# Altium schematic sheet 03_CEM_MBRD.SchDoc
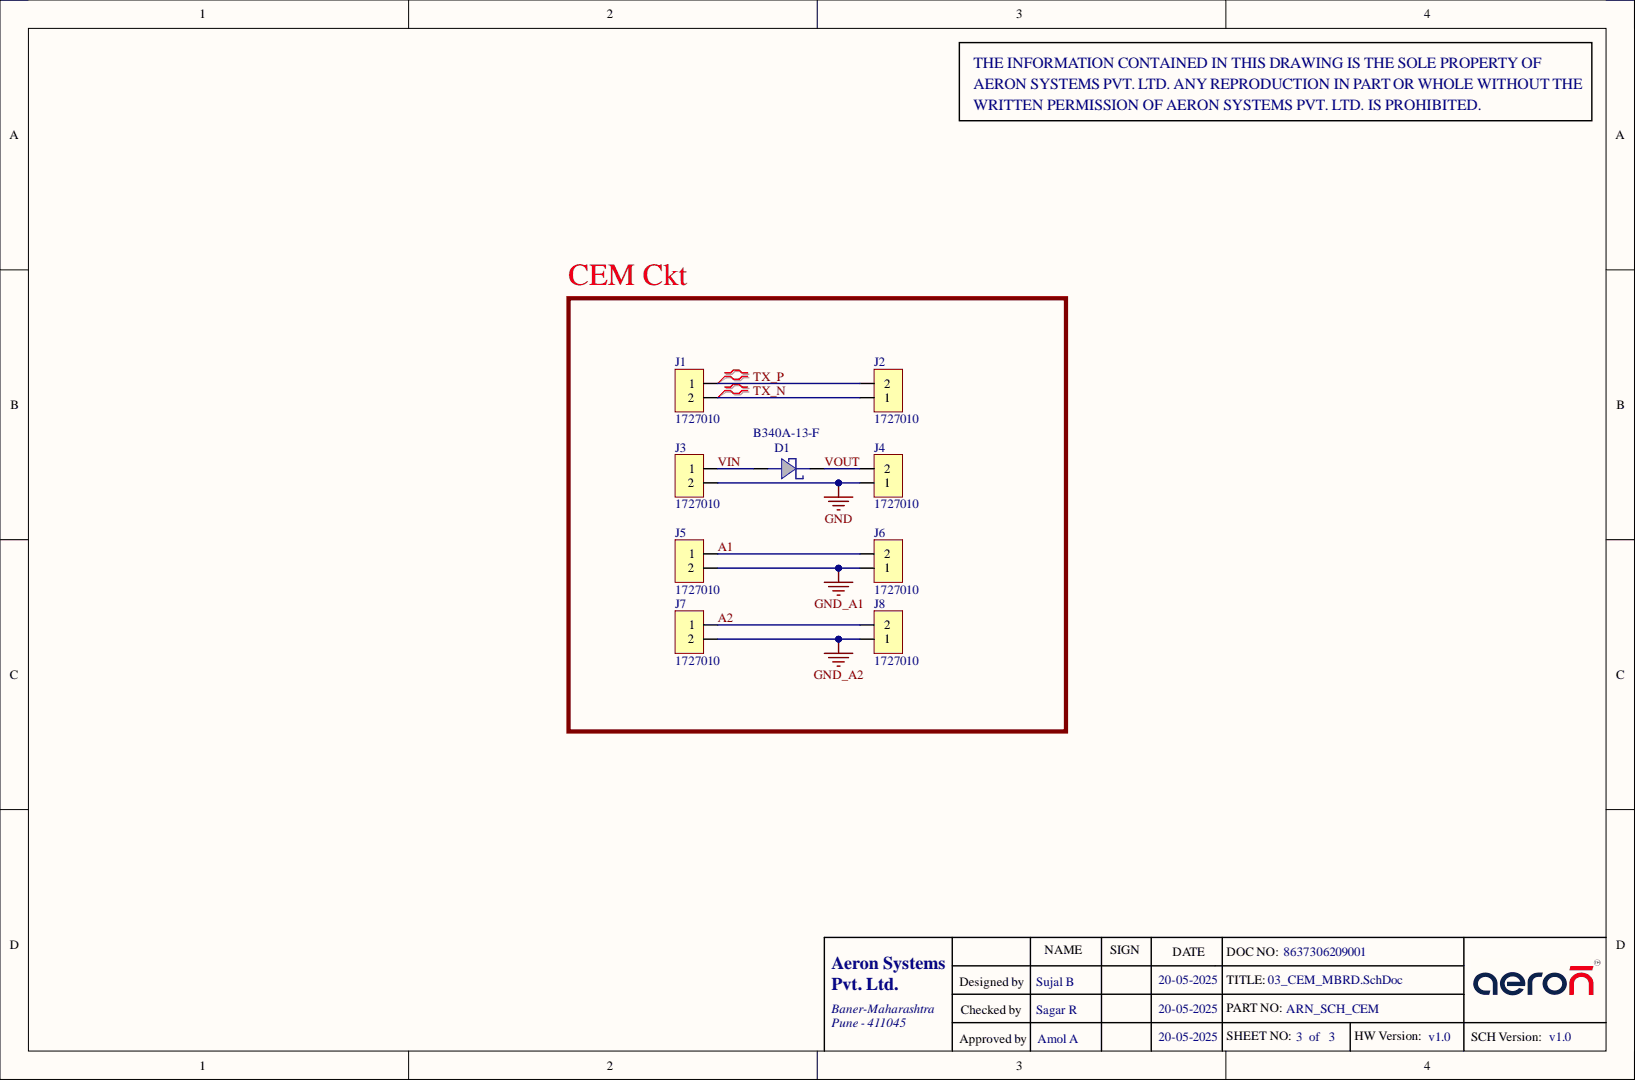
[diagram p0: 03_CEM_MBRD.SchDoc]

## Parts
D1  B340A-13-F  [DO-SMA]
J1  1727010  [CON 2 MKDS-3.81]
J2  1727010  [CON 2 MKDS-3.81]
J3  1727010  [CON 2 MKDS-3.81]
J4  1727010  [CON 2 MKDS-3.81]
J5  1727010  [CON 2 MKDS-3.81]
J6  1727010  [CON 2 MKDS-3.81]
J7  1727010  [CON 2 MKDS-3.81]
J8  1727010  [CON 2 MKDS-3.81]

## Nets
GND: J3.2 J4.1
GND_A1: J5.2 J6.1
GND_A2: J7.2 J8.1
A1: J5.1 J6.2
A2: J7.1 J8.2
TX_N: J1.2 J2.1
TX_P: J1.1 J2.2
VIN: D1.1 J3.1
VOUT: D1.2 J4.2

## Library data of the parts
D1 = Diode B340A-13-F: Schottky Diodes & Rectifiers 40volt 3A DO-SMA; Alternative Part no.: MBRA340T3G; Category/ MIL/ Industrial/: Automotive; Cost for 100: 12.74; Make: Diodes Incorporated; Agent/ Supplier: Mouser; Component Kind: Standard; Manufacturing Part no: B340A-13-F; Operating Temp.: -55°C to +150°C; Package: DO-SMA; Storage temp: -55°C to +150°C; Library Name: ARN_Central_LIB (1).SchLib
J1-J8 = CON 1727010: CON - TH 2pin 3.81mm Screw 12X7.3mm; Alternative Part no.: Phoenix Contact; Category/ MIL/ Industrial/: NA; Cost for 100: ₹83.95; Agent/ Supplier: Mouser; Component Kind: Standard; Item Code: NA; Make: Phoenix Contact; Manufacturing Part no: 1727010; Operating Temp.: -40°C to +100°C; Package: TH_3.81 mm; Library Name: ARN_PRJ_SPIMXX00_MBRD_v1.3-B.SCHLIB
